# Altium schematic sheet LPDDR4.SchDoc
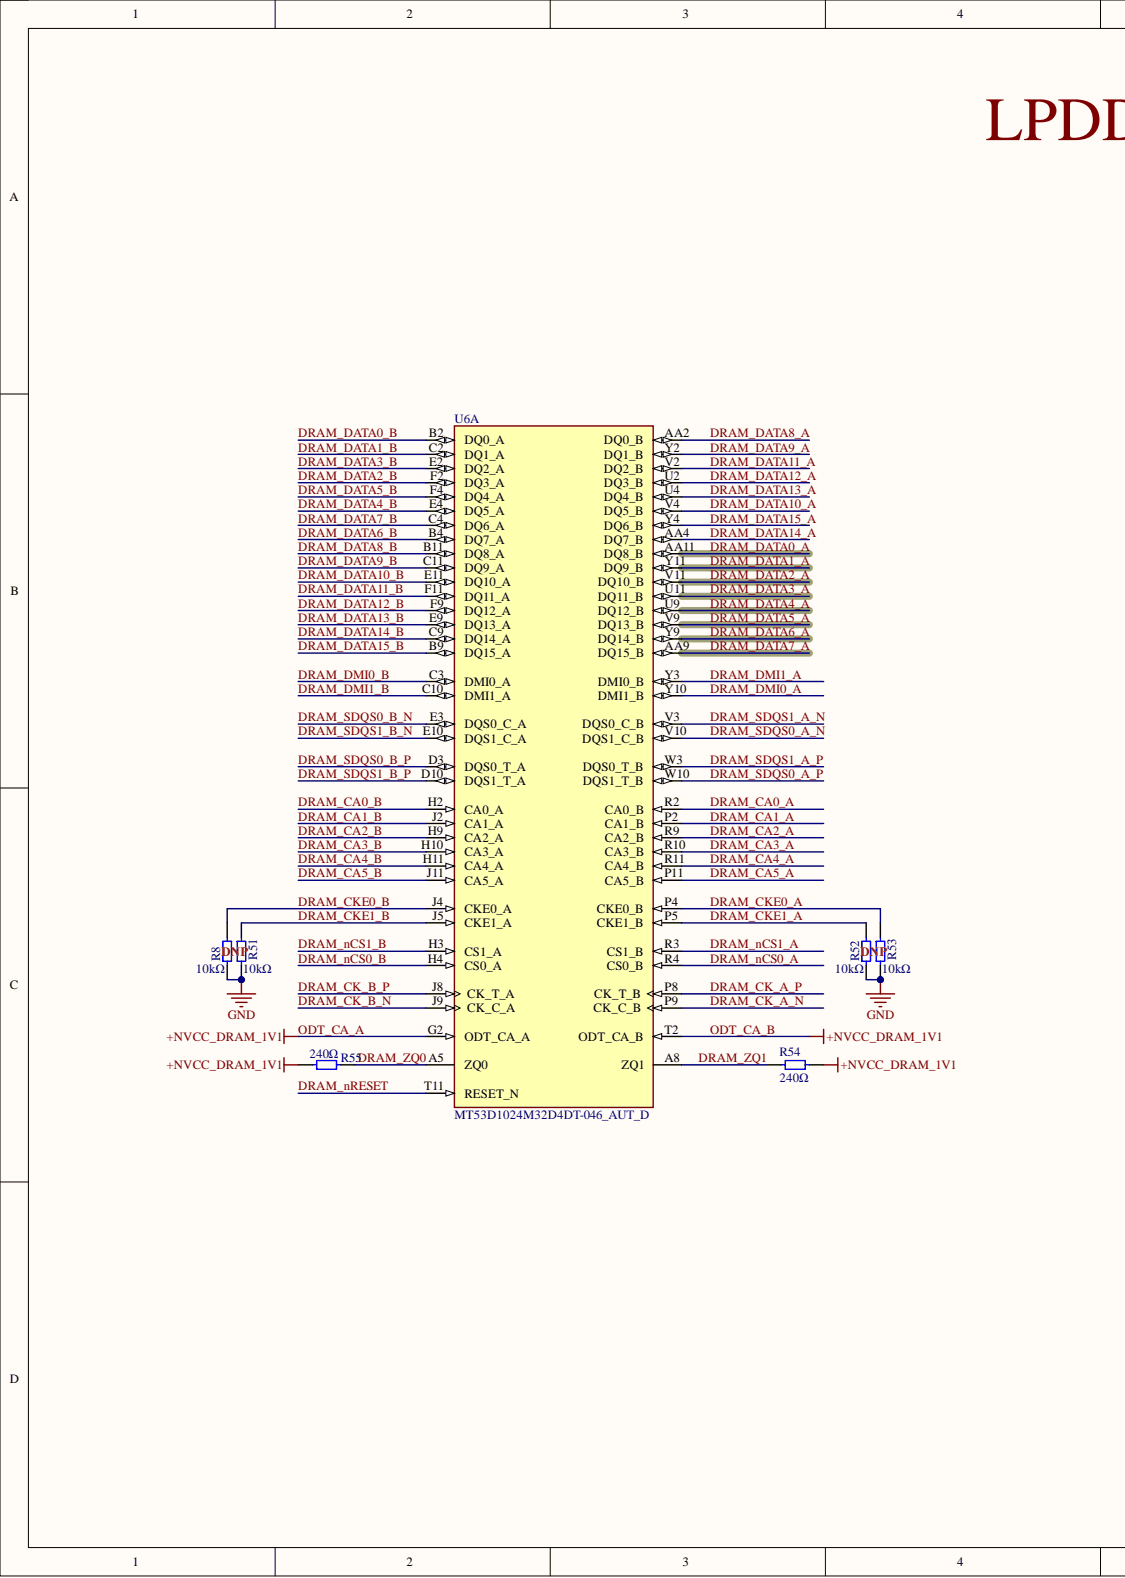
[diagram p0: LPDDR4.SchDoc, left]
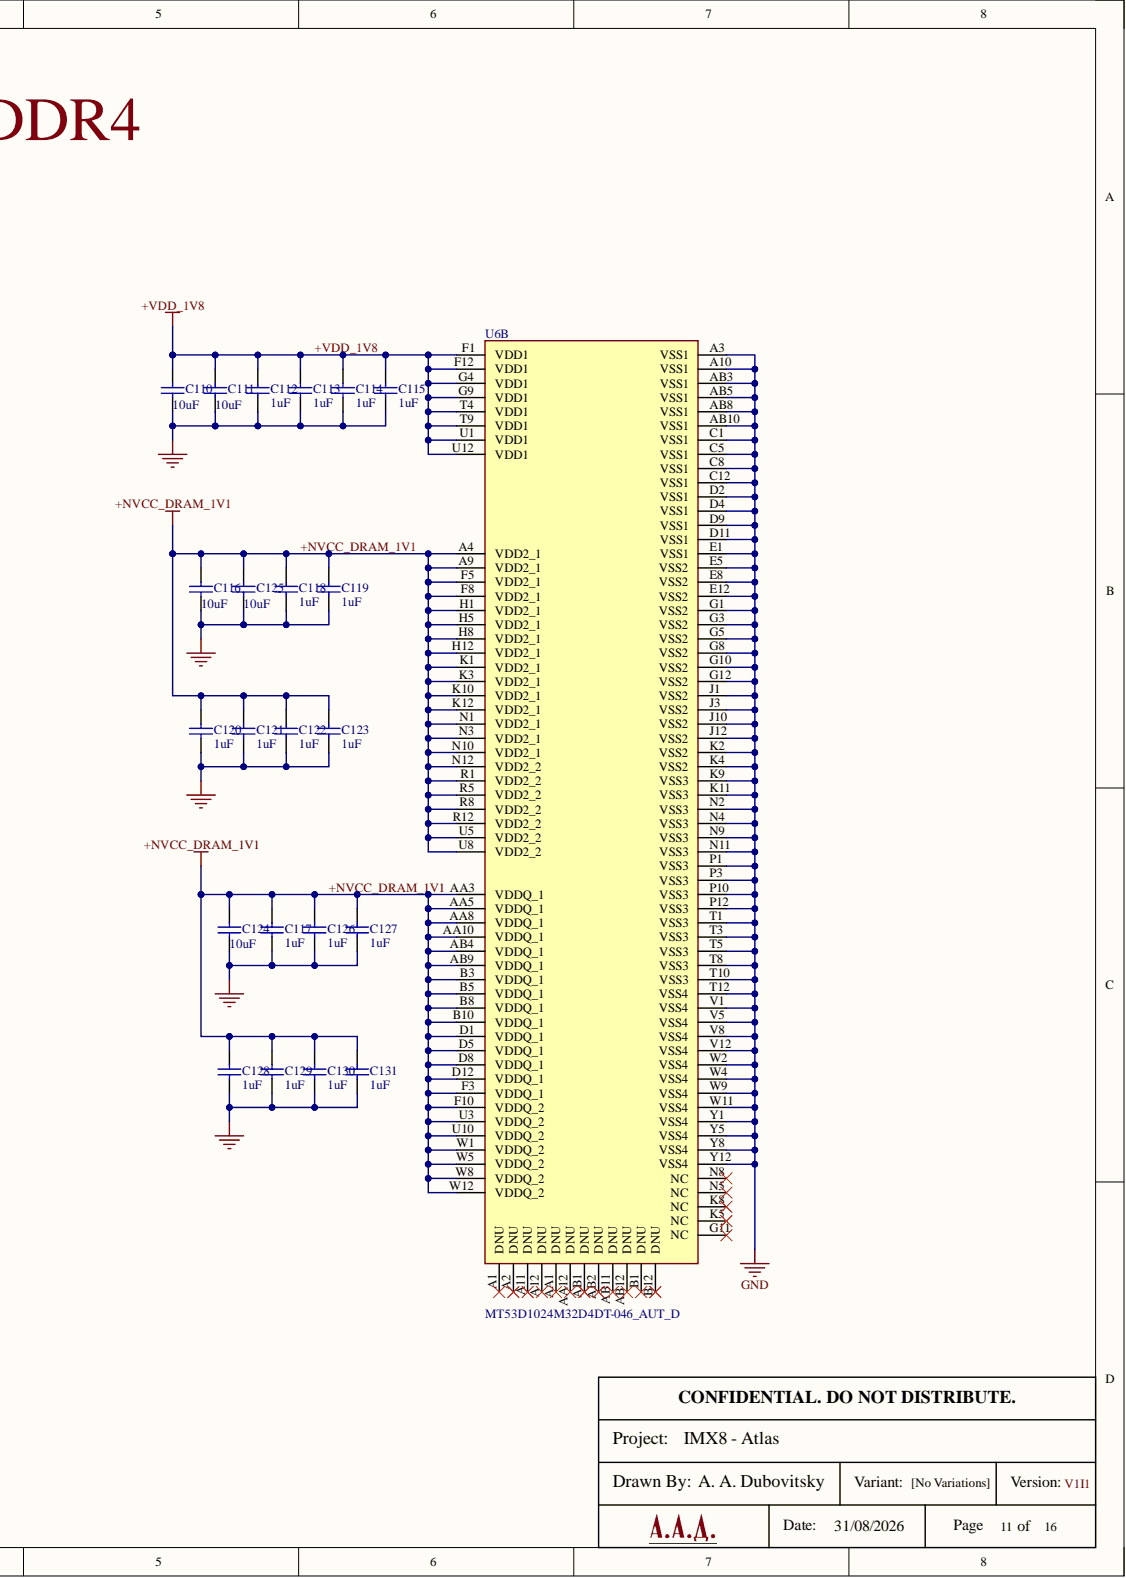
[diagram p1: LPDDR4.SchDoc, right]

## Parts
C110  10uF  [CAP 0402_1005]
C111  10uF  [CAP 0402_1005]
C112  1uF  [CAP 0201_0603 MINI]
C113  1uF  [CAP 0201_0603 MINI]
C114  1uF  [CAP 0201_0603 MINI]
C115  1uF  [CAP 0201_0603 MINI]
C116  10uF  [CAP 0402_1005]
C117  1uF  [CAP 0201_0603 MINI]
C118  1uF  [CAP 0201_0603 MINI]
C119  1uF  [CAP 0201_0603 MINI]
C120  1uF  [CAP 0201_0603 MINI]
C121  1uF  [CAP 0201_0603 MINI]
C122  1uF  [CAP 0201_0603 MINI]
C123  1uF  [CAP 0201_0603 MINI]
C124  10uF  [CAP 0402_1005]
C125  10uF  [CAP 0402_1005]
C126  1uF  [CAP 0201_0603 MINI]
C127  1uF  [CAP 0201_0603 MINI]
C128  1uF  [CAP 0201_0603 MINI]
C129  1uF  [CAP 0201_0603 MINI]
C130  1uF  [CAP 0201_0603 MINI]
C131  1uF  [CAP 0201_0603 MINI]
R8  10kΩ  [RES 0201_0603]
R51  10kΩ  [RES 0201_0603]
R52  10kΩ  [RES 0201_0603]
R53  10kΩ  [RES 0201_0603]
R54  240Ω  [RES 0201_0603]
R55  240Ω  [RES 0201_0603]
U6  MT53D1024M32D4DT-046_AUT_D  [IC_MT53D1024M32D4DT-046_AUT_D]  (parts A,B)

## Nets
GND: C110.1 C111.1 C112.1 C113.1 C114.1 C115.1 C116.1 C117.1 C118.1 C119.1 C120.1 C121.1 C122.1 C123.1 C124.1 C125.1 C126.1 C127.1 C128.1 C129.1 C130.1 C131.1 R8.1 R51.1 R52.1 R53.1 U6.A3(VSS1) U6.A10(VSS1) U6.AB3(VSS1) U6.AB5(VSS1) U6.AB8(VSS1) U6.AB10(VSS1) U6.C1(VSS1) U6.C5(VSS1) U6.C8(VSS1) U6.C12(VSS1) U6.D2(VSS1) U6.D4(VSS1) U6.D9(VSS1) U6.D11(VSS1) U6.E1(VSS1) U6.E5(VSS2) U6.E8(VSS2) U6.E12(VSS2) U6.G1(VSS2) U6.G3(VSS2) U6.G5(VSS2) U6.G8(VSS2) U6.G10(VSS2) U6.G12(VSS2) U6.J1(VSS2) U6.J3(VSS2) U6.J10(VSS2) U6.J12(VSS2) U6.K2(VSS2) U6.K4(VSS2) U6.K9(VSS3) U6.K11(VSS3) U6.N2(VSS3) U6.N4(VSS3) U6.N9(VSS3) U6.N11(VSS3) U6.P1(VSS3) U6.P3(VSS3) U6.P10(VSS3) U6.P12(VSS3) U6.T1(VSS3) U6.T3(VSS3) U6.T5(VSS3) U6.T8(VSS3) U6.T10(VSS3) U6.T12(VSS4) U6.V1(VSS4) U6.V5(VSS4) U6.V8(VSS4) U6.V12(VSS4) U6.W2(VSS4) U6.W4(VSS4) U6.W9(VSS4) U6.W11(VSS4) U6.Y1(VSS4) U6.Y5(VSS4) U6.Y8(VSS4) U6.Y12(VSS4)
+NVCC_DRAM_1V1: C116.2 C117.2 C118.2 C119.2 C120.2 C121.2 C122.2 C123.2 C124.2 C125.2 C126.2 C127.2 C128.2 C129.2 C130.2 C131.2 R54.2 R55.2 U6.A4(VDD2_1) U6.A9(VDD2_1) U6.AA3(VDDQ_1) U6.AA5(VDDQ_1) U6.AA8(VDDQ_1) U6.AA10(VDDQ_1) U6.AB4(VDDQ_1) U6.AB9(VDDQ_1) U6.B3(VDDQ_1) U6.B5(VDDQ_1) U6.B8(VDDQ_1) U6.B10(VDDQ_1) U6.D1(VDDQ_1) U6.D5(VDDQ_1) U6.D8(VDDQ_1) U6.D12(VDDQ_1) U6.F3(VDDQ_1) U6.F5(VDD2_1) U6.F8(VDD2_1) U6.F10(VDDQ_2) U6.G2(ODT_CA_A) U6.H1(VDD2_1) U6.H5(VDD2_1) U6.H8(VDD2_1) U6.H12(VDD2_1) U6.K1(VDD2_1) U6.K3(VDD2_1) U6.K10(VDD2_1) U6.K12(VDD2_1) U6.N1(VDD2_1) U6.N3(VDD2_1) U6.N10(VDD2_1) U6.N12(VDD2_2) U6.R1(VDD2_2) U6.R5(VDD2_2) U6.R8(VDD2_2) U6.R12(VDD2_2) U6.T2(ODT_CA_B) U6.U3(VDDQ_2) U6.U5(VDD2_2) U6.U8(VDD2_2) U6.U10(VDDQ_2) U6.W1(VDDQ_2) U6.W5(VDDQ_2) U6.W8(VDDQ_2) U6.W12(VDDQ_2)
+VDD_1V8: C110.2 C111.2 C112.2 C113.2 C114.2 C115.2 U6.F1(VDD1) U6.F12(VDD1) U6.G4(VDD1) U6.G9(VDD1) U6.T4(VDD1) U6.T9(VDD1) U6.U1(VDD1) U6.U12(VDD1)
DRAM_CA0_A: U6.R2(CA0_B)
DRAM_CA0_B: U6.H2(CA0_A)
DRAM_CA1_A: U6.P2(CA1_B)
DRAM_CA1_B: U6.J2(CA1_A)
DRAM_CA2_A: U6.R9(CA2_B)
DRAM_CA2_B: U6.H9(CA2_A)
DRAM_CA3_A: U6.R10(CA3_B)
DRAM_CA3_B: U6.H10(CA3_A)
DRAM_CA4_A: U6.R11(CA4_B)
DRAM_CA4_B: U6.H11(CA4_A)
DRAM_CA5_A: U6.P11(CA5_B)
DRAM_CA5_B: U6.J11(CA5_A)
DRAM_CK_A_N: U6.P9(CK_C_B)
DRAM_CK_A_P: U6.P8(CK_T_B)
DRAM_CK_B_N: U6.J9(CK_C_A)
DRAM_CK_B_P: U6.J8(CK_T_A)
DRAM_CKE0_A: R53.2 U6.P4(CKE0_B)
DRAM_CKE0_B: R8.2 U6.J4(CKE0_A)
DRAM_CKE1_A: R52.2 U6.P5(CKE1_B)
DRAM_CKE1_B: R51.2 U6.J5(CKE1_A)
DRAM_DATA0_A: U6.AA11(DQ8_B)
DRAM_DATA0_B: U6.B2(DQ0_A)
DRAM_DATA1_A: U6.Y11(DQ9_B)
DRAM_DATA1_B: U6.C2(DQ1_A)
DRAM_DATA2_A: U6.V11(DQ10_B)
DRAM_DATA2_B: U6.F2(DQ3_A)
DRAM_DATA3_A: U6.U11(DQ11_B)
DRAM_DATA3_B: U6.E2(DQ2_A)
DRAM_DATA4_A: U6.U9(DQ12_B)
DRAM_DATA4_B: U6.E4(DQ5_A)
DRAM_DATA5_A: U6.V9(DQ13_B)
DRAM_DATA5_B: U6.F4(DQ4_A)
DRAM_DATA6_A: U6.Y9(DQ14_B)
DRAM_DATA6_B: U6.B4(DQ7_A)
DRAM_DATA7_A: U6.AA9(DQ15_B)
DRAM_DATA7_B: U6.C4(DQ6_A)
DRAM_DATA8_A: U6.AA2(DQ0_B)
DRAM_DATA8_B: U6.B11(DQ8_A)
DRAM_DATA9_A: U6.Y2(DQ1_B)
DRAM_DATA9_B: U6.C11(DQ9_A)
DRAM_DATA10_A: U6.V4(DQ5_B)
DRAM_DATA10_B: U6.E11(DQ10_A)
DRAM_DATA11_A: U6.V2(DQ2_B)
DRAM_DATA11_B: U6.F11(DQ11_A)
DRAM_DATA12_A: U6.U2(DQ3_B)
DRAM_DATA12_B: U6.F9(DQ12_A)
DRAM_DATA13_A: U6.U4(DQ4_B)
DRAM_DATA13_B: U6.E9(DQ13_A)
DRAM_DATA14_A: U6.AA4(DQ7_B)
DRAM_DATA14_B: U6.C9(DQ14_A)
DRAM_DATA15_A: U6.Y4(DQ6_B)
DRAM_DATA15_B: U6.B9(DQ15_A)
DRAM_DMI0_A: U6.Y10(DMI1_B)
DRAM_DMI0_B: U6.C3(DMI0_A)
DRAM_DMI1_A: U6.Y3(DMI0_B)
DRAM_DMI1_B: U6.C10(DMI1_A)
DRAM_nCS0_A: U6.R4(CS0_B)
DRAM_nCS0_B: U6.H4(CS0_A)
DRAM_nCS1_A: U6.R3(CS1_B)
DRAM_nCS1_B: U6.H3(CS1_A)
DRAM_nRESET: U6.T11(RESET_N)
DRAM_SDQS0_A_N: U6.V10(DQS1_C_B)
DRAM_SDQS0_A_P: U6.W10(DQS1_T_B)
DRAM_SDQS0_B_N: U6.E3(DQS0_C_A)
DRAM_SDQS0_B_P: U6.D3(DQS0_T_A)
DRAM_SDQS1_A_N: U6.V3(DQS0_C_B)
DRAM_SDQS1_A_P: U6.W3(DQS0_T_B)
DRAM_SDQS1_B_N: U6.E10(DQS1_C_A)
DRAM_SDQS1_B_P: U6.D10(DQS1_T_A)
DRAM_ZQ0: R55.1 U6.A5(ZQ0)
DRAM_ZQ1: R54.1 U6.A8(ZQ1)

Not connected: U6 A1(DNU) A2(DNU) A11(DNU) A12(DNU) AA1(DNU) AA12(DNU) AB1(DNU) AB2(DNU) AB11(DNU) AB12(DNU) B1(DNU) B12(DNU) G11(NC) K5(NC) K8(NC) N5(NC) N8(NC)

## Library data of the parts
C110 C111 C116 C124 C125 = C2846677: Cap. 10uF 10V ±20% 0402; Component Kind: Standard; Library Name: Atlas - Symbols.SchLib
C112-C115 C117-C123 C126-C131 = C6119758: Cap. 1uF 10V ±20% 0201; Component Kind: Standard; Library Name: Atlas - Symbols.SchLib
R8 R51-R53 = C138117: Res. 10kΩ 25V 50mW ±5% 0201; Component Kind: Standard; Library Name: Atlas - Symbols.SchLib
R54 R55 = C155745: Res. 240Ω 25V 50mW ±1% 0201; Component Kind: Standard; Library Name: Atlas - Symbols.SchLib
U6 = MT53D1024M32D4DT-046_AUT_D: SDRAM - Mobile LPDDR4 Memory IC 32Gbit 2.133 GHz 200-VFBGA (10x14.5); MF: Micron Technology Inc.; MP: MT53D1024M32D4DT-046 AUT:D; Check_prices: https://www.snapeda.com/parts/MT53D1024M32D4DT-046%20AUT:D/Micron/view-part/?ref=eda; Component Kind: Standard; Package: None; SnapEDA_Link: https://www.snapeda.com/parts/MT53D1024M32D4DT-046%20AUT:D/Micron/view-part/?ref=snap; Library Name: Atlas - Symbols.SchLib
